# Altium schematic sheet ESP32.SchDoc
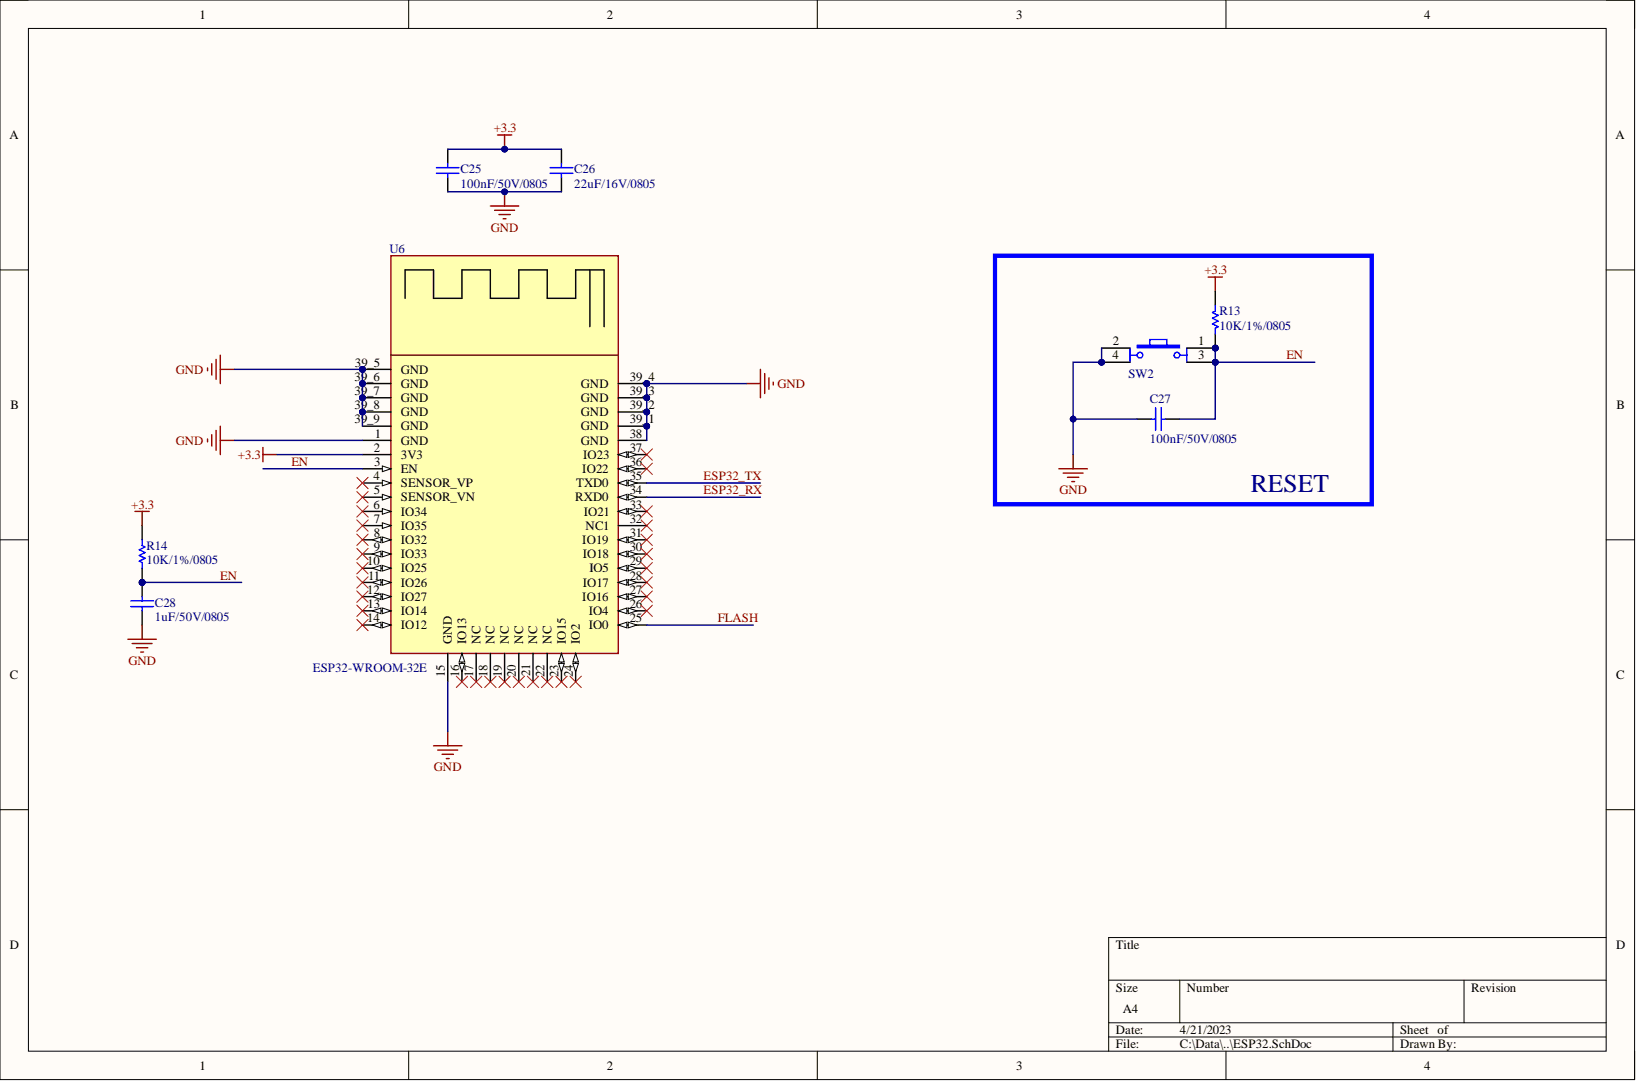
[diagram p0: ESP32.SchDoc]

## Parts
C25  value 100nF/50V/0805  [CAP-SM-0805]  (hidden comment: Cap Non-Pol SMD)
C26  value 22uF/16V/0805  [CAP-SM-0805]  (hidden comment: Cap Non-Pol SMD)
C27  value 100nF/50V/0805  [CAP-SM-0805]  (hidden comment: Cap Non-Pol SMD)
C28  value 1uF/50V/0805  [CAP-SM-0805]  (hidden comment: Cap Non-Pol SMD)
R13  value 10K/1%/0805  [RES-SM-0805]  (hidden comment: RESISTOR SMD)
R14  value 10K/1%/0805  [RES-SM-0805]  (hidden comment: RESISTOR SMD)
SW2  SW  [Tact SW 4 pin]
U6  ESP32-WROOM-32E  [ESP32-WROOM-32E]

## Nets
+3.3: C25.1 C26.1 R13.2 R14.2 U6.2(3V3)
GND: C25.2 C26.2 C27.2 C28.1 SW2.2 SW2.4 U6.1(GND) U6.15(GND) U6.38(GND) U6.39_1(GND) U6.39_2(GND) U6.39_3(GND) U6.39_4(GND) U6.39_5(GND) U6.39_6(GND) U6.39_7(GND) U6.39_8(GND) U6.39_9(GND)
EN: C27.1 C28.2 R13.1 R14.1 SW2.1 SW2.3 U6.3(EN)
ESP32_RX: U6.34(RXD0)
ESP32_TX: U6.35(TXD0)
FLASH: U6.25(IO0)

Not connected: U6 4(SENSOR_VP) 5(SENSOR_VN) 6(IO34) 7(IO35) 8(IO32) 9(IO33) 10(IO25) 11(IO26) 12(IO27) 13(IO14) 14(IO12) 16(IO13) 17(NC) 18(NC) 19(NC) 20(NC) 21(NC) 22(NC) 23(IO15) 24(IO2) 26(IO4) 27(IO16) 28(IO17) 29(IO5) 30(IO18) 31(IO19) 32(NC1) 33(IO21) 36(IO22) 37(IO23)

## Library data of the parts
C25-C28 = Cap Non-Pol SMD: Capacitor; Component Kind: Standard; Library Name: PIF_Library.IntLib
R13 R14 = RESISTOR SMD: Resistor; Component Kind: Standard; Library Name: PIF_Library.IntLib
SW2 = Tact SW - 4Pin: Switch button 4 pin; Value: Button chip 4 pin; Component Kind: Standard; Library Name: PIF_Library.IntLib
U6 = ESP32-WROOM-32E: Component Kind: Standard; Library Name: Giang's Libs.IntLib
